# Altium schematic sheet POWER.SchDoc
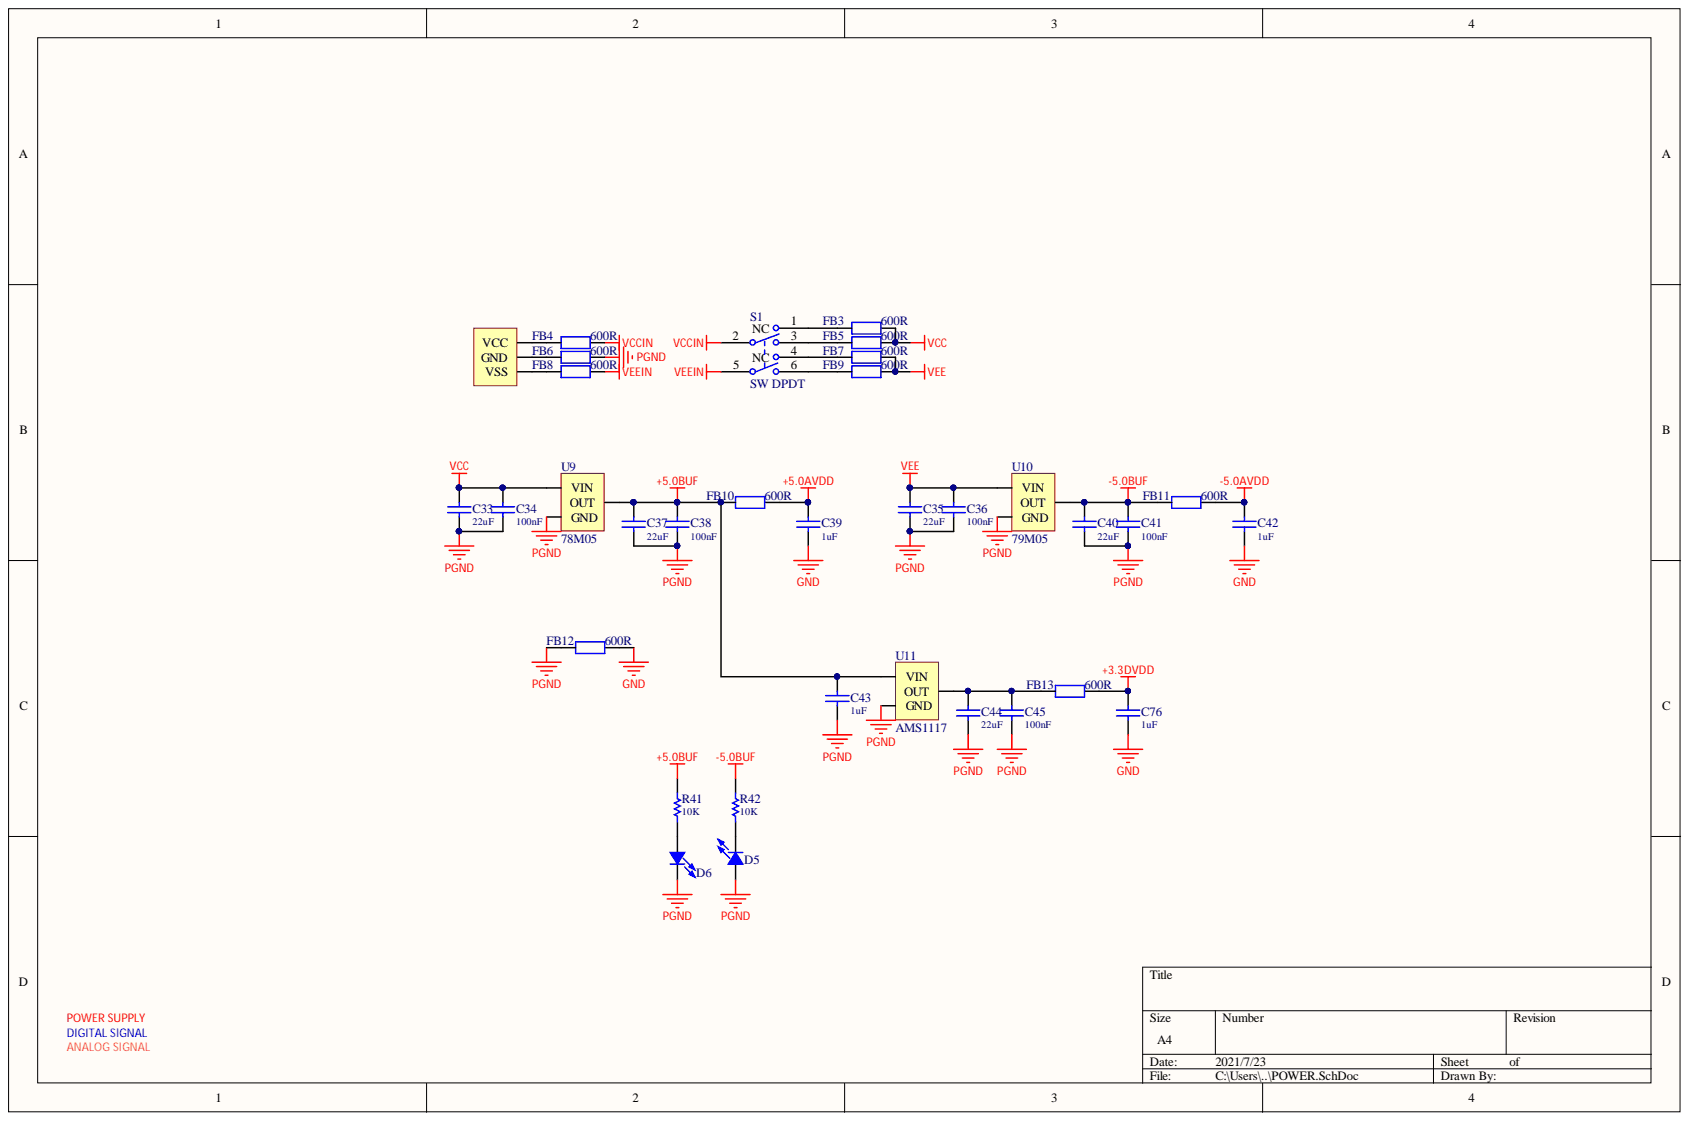
[diagram p0: POWER.SchDoc]

## Parts
C33  value 22uF  [C0805-L]  (hidden comment: Cap-S)
C34  value 100nF  [C0603-L]  (hidden comment: Cap-S)
C35  value 22uF  [C0805-L]  (hidden comment: Cap-S)
C36  value 100nF  [C0603-L]  (hidden comment: Cap-S)
C37  value 22uF  [C0805-L]  (hidden comment: Cap-S)
C38  value 100nF  [C0603-L]  (hidden comment: Cap-S)
C39  value 1uF  [C0603-L]  (hidden comment: Cap-S)
C40  value 22uF  [C0805-L]  (hidden comment: Cap-S)
C41  value 100nF  [C0603-L]  (hidden comment: Cap-S)
C42  value 1uF  [C0603-L]  (hidden comment: Cap-S)
C43  value 1uF  [C0603-L]  (hidden comment: Cap-S)
C44  value 22uF  [C0805-L]  (hidden comment: Cap-S)
C45  value 100nF  [C0603-L]  (hidden comment: Cap-S)
C76  value 1uF  [C0603-L]  (hidden comment: Cap-S)
D5  LED  [Led-0805_L]  (value not drawn)
D6  LED  [Led-0805_L]  (value not drawn)
FB3  600R  [FB-0805]
FB4  600R  [FB-0805]
FB5  600R  [FB-0805]
FB6  600R  [FB-0805]
FB7  600R  [FB-0805]
FB8  600R  [FB-0805]
FB9  600R  [FB-0805]
FB10  600R  [FB-0805]
FB11  600R  [FB-0805]
FB12  600R  [FB-0805]
FB13  600R  [FB-0805]
J2  Power-3P  [SIPA03]  (value not drawn)
R41  R  value 10K  [R0603-L]
R42  R  value 10K  [R0603-L]
S1  SW DPDT  [SW_DPDT]
U9  78M05  [TO-252]
U10  79M05  [TO-252]
U11  AMS1117  [SOT-223]

## Nets
+3.3DVDD: C76.2 FB13.2
+5.0AVDD: C39.2 FB10.2
+5.0BUF: C37.2 C38.2 C43.2 FB10.1 R41.2 U9.3 U11.3
-5.0AVDD: C42.2 FB11.2
-5.0BUF: C40.2 C41.2 FB11.1 R42.2 U10.3
GND: C39.1 C42.1 C76.1 FB12.2
PGND: C33.1 C34.1 C35.1 C36.1 C37.1 C38.1 C40.1 C41.1 C43.1 C44.1 C45.1 D5.1 D6.2 FB6.2 FB12.1 U9.2 U10.1 U11.1
VCC: C33.2 C34.2 FB3.2 FB5.2 U9.1
VCCIN: FB4.2 S1.2
VEE: C35.2 C36.2 FB7.2 FB9.2 U10.2
VEEIN: FB8.2 S1.5
NetC44_2: C44.2 C45.2 FB13.1 U11.2
NetD5_2: D5.2 R42.1
NetD6_1: D6.1 R41.1
NetFB3_1: FB3.1 S1.1(NC)
NetFB4_1: FB4.1 J2.1
NetFB5_1: FB5.1 S1.3
NetFB6_1: FB6.1 J2.2
NetFB7_1: FB7.1 S1.4(NC)
NetFB8_1: FB8.1 J2.3
NetFB9_1: FB9.1 S1.6
ANALOG SIGNAL:
DIGITAL SIGNAL:
POWER SUPPLY:

## Library data of the parts
C33-C45 C76 = Cap-S: 贴片瓷片电容; Component Kind: Standard; Library Name: xz_D.IntLib
D5 D6 = LED: 发光二极管; Component Kind: Standard; Library Name: CommonUsed.IntLib
FB3-FB13 = FB: Component Kind: Standard; Library Name: CommonUsed.IntLib
J2 = Power-3P: 供电端子; Component Kind: Standard; Library Name: xz_C.IntLib
R41 R42 = Res: 贴片电阻; Component Kind: Standard; Library Name: xz_D.IntLib
S1 = SW DPDT: 自锁开关; Code_IPC: SOT26A-6; Code_JEITA: SC-74; Component Kind: Standard; LatestRevisionDate: 29-May-2009; LatestRevisionNote: IPC-7351 Footprint Added.; PackageDescription: 6-Pin SOT-23 Package 0.95 mm Pitch, 2.7 mm Lead Span; PackageReference: SOT23-6; PackageVersion: Mar-2001; Published: 24-Mar-1999; Library Name: xz_D.IntLib; Publisher: Altium Limited
U9 = 78M05: Component Kind: Standard; Library Name: PowerUnits.IntLib
U10 = 79M05: Component Kind: Standard; Library Name: PowerUnits.IntLib
U11 = AMS1117: Component Kind: Standard; Library Name: CommonUsed.IntLib
